# Altium schematic sheet Extension.SchDoc(Extension)
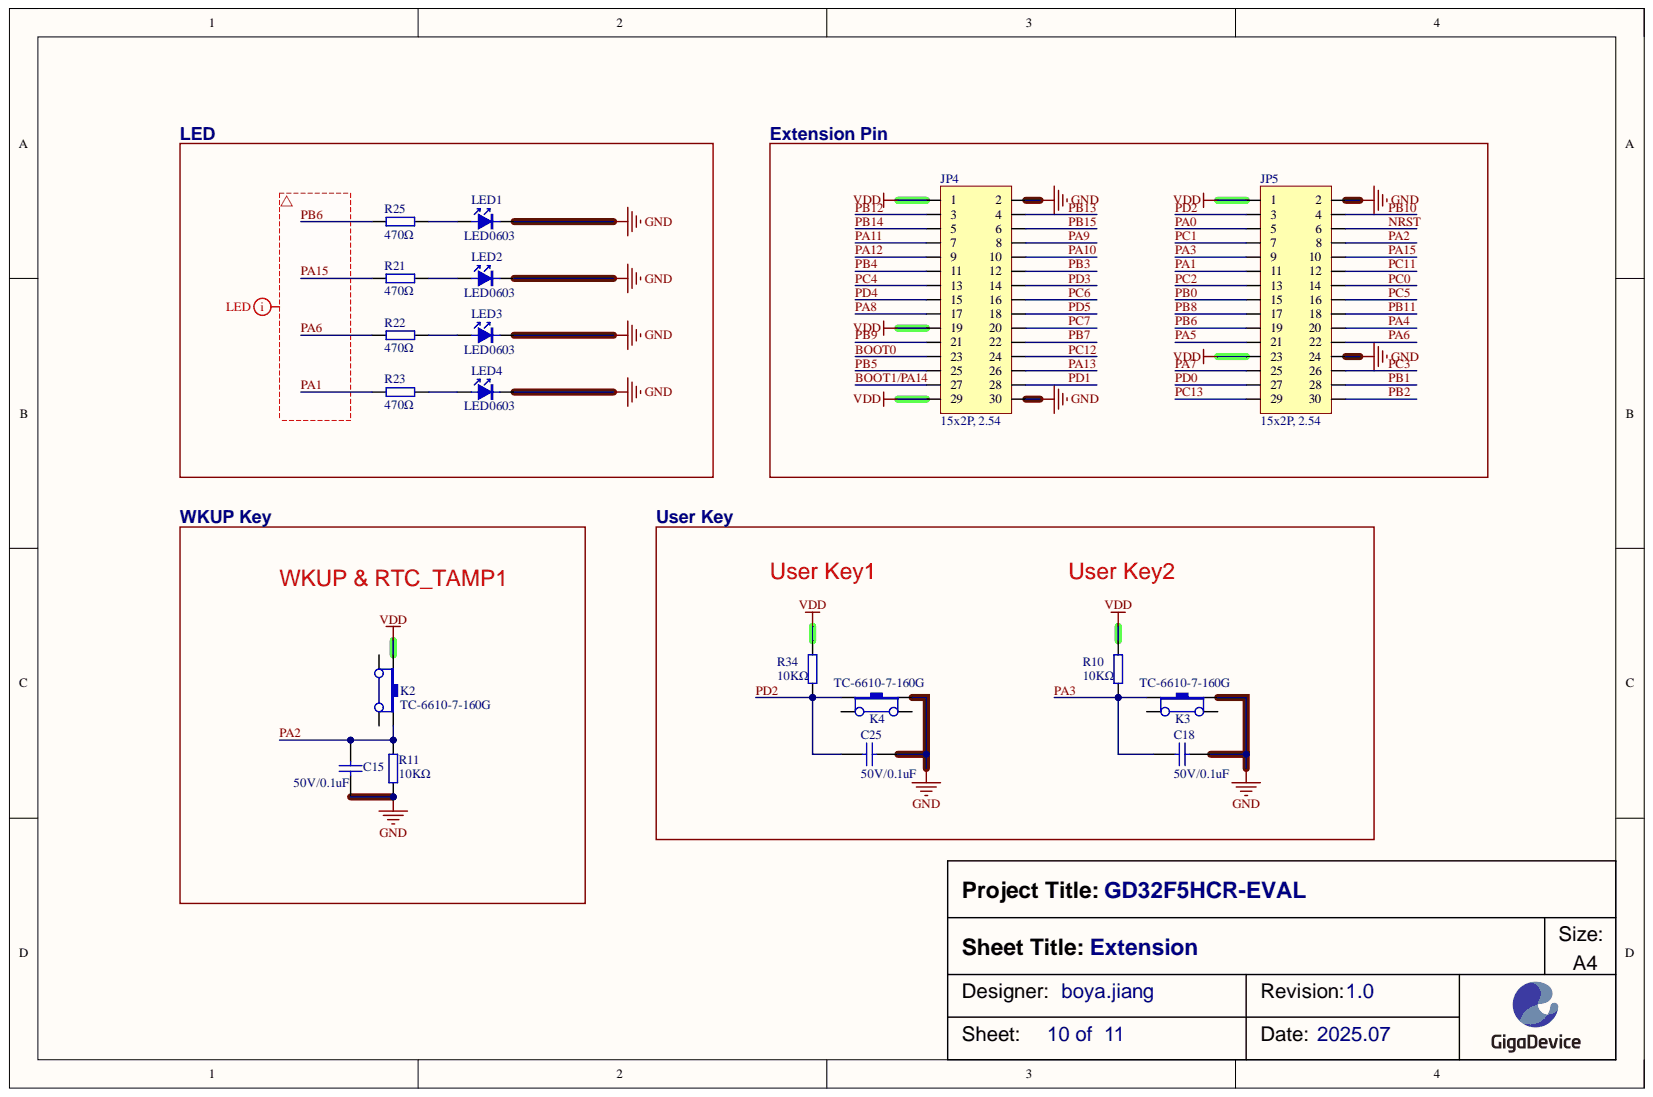
[diagram p0: Extension.SchDoc]

## Parts
C15  50V/0.1uF  [0603-C]
C18  50V/0.1uF  [0603-C]
C25  50V/0.1uF  [0603-C]
JP4  15x2P, 2.54  [IDC-30]
JP5  15x2P, 2.54  [IDC-30]
K2  TC-6610-7-160G  [K-1102B]
K3  TC-6610-7-160G  [K-1102B]
K4  TC-6610-7-160G  [K-1102B]
LED1  NCD0603C1(黄绿)  [0603-LED-Green]  (value not drawn)
LED2  NCD0603C1(黄绿)  [0603-LED-Green]  (value not drawn)
LED3  NCD0603C1(黄绿)  [0603-LED-Green]  (value not drawn)
LED4  NCD0603C1(黄绿)  [0603-LED-Green]  (value not drawn)
R10  10KΩ  [0603-R]
R11  10KΩ  [0603-R]
R21  470Ω  [0603-R]
R22  470Ω  [0603-R]
R23  470Ω  [0603-R]
R25  470Ω  [0603-R]
R34  10KΩ  [0603-R]

## Nets
GND: C15.1 C18.2 C25.2 JP4.2 JP4.30 JP5.2 JP5.24 K3.3 K4.3 LED1.K LED2.K LED3.K LED4.K R11.2
VDD: JP4.1 JP4.19 JP4.29 JP5.1 JP5.23 K2.1 R10.1 R34.1
BOOT0: JP4.23
BOOT1/PA14: JP4.27
NRST: JP5.6
PA0: JP5.5
PA1: JP5.11 R23.1
PA2: C15.2 JP5.8 K2.3 R11.1
PA3: C18.1 JP5.9 K3.1 R10.2
PA4: JP5.20
PA5: JP5.21
PA6: JP5.22 R22.1
PA7: JP5.25
PA8: JP4.17
PA9: JP4.8
PA10: JP4.10
PA11: JP4.7
PA12: JP4.9
PA13: JP4.26
PA15: JP5.10 R21.1
PB0: JP5.15
PB1: JP5.28
PB2: JP5.30
PB3: JP4.12
PB4: JP4.11
PB5: JP4.25
PB6: JP5.19 R25.1
PB7: JP4.22
PB8: JP5.17
PB9: JP4.21
PB10: JP5.4
PB11: JP5.18
PB12: JP4.3
PB13: JP4.4
PB14: JP4.5
PB15: JP4.6
PC0: JP5.14
PC1: JP5.7
PC2: JP5.13
PC3: JP5.26
PC4: JP4.13
PC5: JP5.16
PC6: JP4.16
PC7: JP4.20
PC11: JP5.12
PC12: JP4.24
PC13: JP5.29
PD0: JP5.27
PD1: JP4.28
PD2: C25.1 JP5.3 K4.1 R34.2
PD3: JP4.14
PD4: JP4.15
PD5: JP4.18
NetLED1_A: LED1.A R25.2
NetLED2_A: LED2.A R21.2
NetLED3_A: LED3.A R22.2
NetLED4_A: LED4.A R23.2

Not connected: K2 2 4; K3 2 4; K4 2 4

## Library data of the parts
C15 C18 C25 = 50V/0.1uF: 表贴电容; Component Kind: Standard; Library Name: KLTC-电容.SchLib
JP4 JP5 = HEADER 15x2: 双排插针; Note: 不焊; Component Kind: Standard; Library Name: boyajiang.SchLib
K2-K4 = K-1102B: 直插6*6*7mm轻触按键开关, 单刀单掷, 1.6N; Component Kind: Standard; Library Name: KLTC-按键及接插件.SchLib
LED1-LED4 = LED0603: 表贴LED发光管; Component Kind: Standard; Footprint For: LED0603; Library Name: xufei.SchLib
R10 R11 R34 = 10KΩ: 表贴电阻; Component Kind: Standard; Library Name: KLTC-电阻.SchLib
R21-R23 R25 = 470Ω: 表贴电阻; Component Kind: Standard; Library Name: KLTC-电阻.SchLib
